# Altium schematic sheet H723ZG_Interface.SchDoc(H723ZG_Interface)
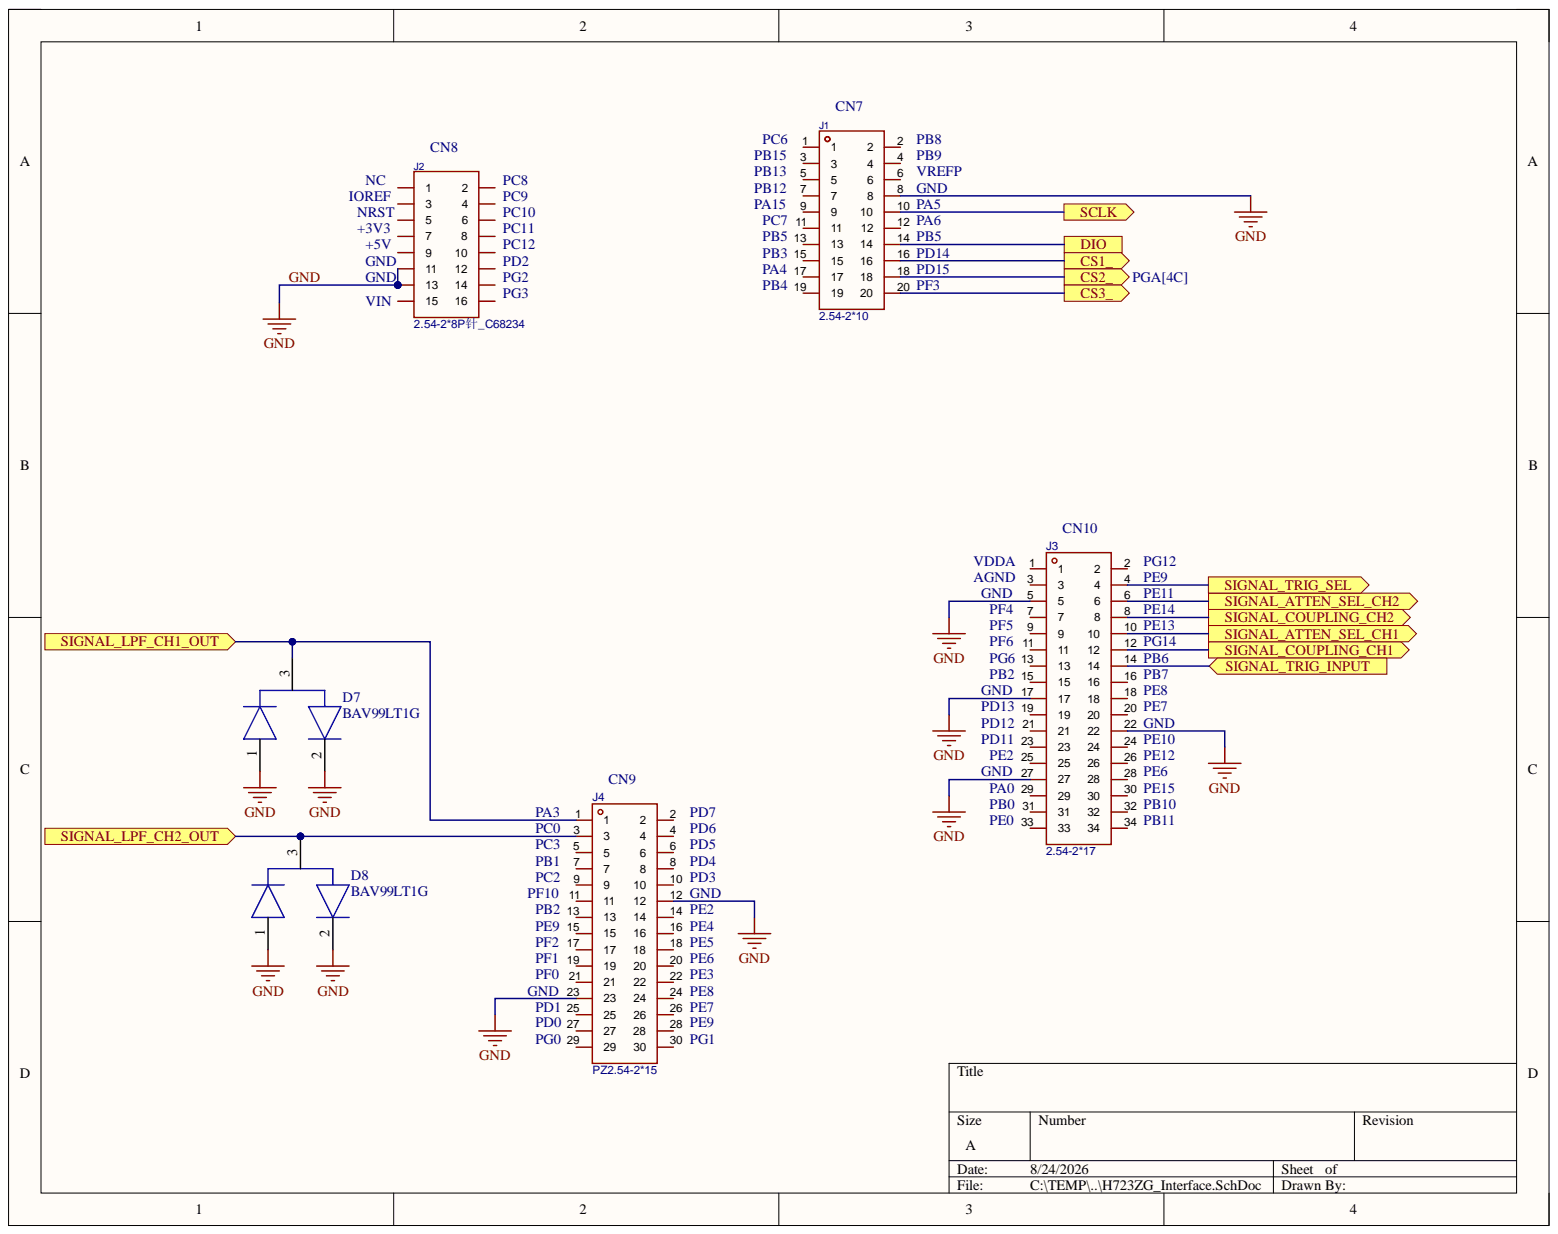
[diagram p0: H723ZG_Interface.SchDoc]

## Parts
D7  BAV99LT1G  [FP-318-08-IPC_B]
D8  BAV99LT1G  [FP-318-08-IPC_B]
J1  2.54-2*10  [HDR-TH_20P-P2.54-V-M-R2-C10-S2.54]
J2  2.54-2*8P?_C68234  [HDR-TH_16P-P2.54-V-M-R2-C8-S2.54]  (value not drawn)
J3  2.54-2*17  [HDR-TH_34P-P2.54-V-M-R2-C17-S2.54-2]
J4  PZ2.54-2*15  [HDR-TH_30P-P2.54-V-M-R2-C15-S2.54-2]

## Ports
CS1_, CS2_, CS3_, DIO, SCLK, SIGNAL_ATTEN_SEL_CH1, SIGNAL_ATTEN_SEL_CH2, SIGNAL_COUPLING_CH1, SIGNAL_COUPLING_CH2, SIGNAL_LPF_CH1_OUT, SIGNAL_LPF_CH2_OUT, SIGNAL_TRIG_INPUT, SIGNAL_TRIG_SEL

## Nets
CS1_: J1.16 ; port CS1_
CS2_: J1.18 ; port CS2_
CS3_: J1.20 ; port CS3_
DIO: J1.14 ; port DIO
GND: D7.1 D7.2 D8.1 D8.2 J1.8 J2.11 J2.13 J3.5 J3.17 J3.22 J3.27 J4.12 J4.23
SCLK: J1.10 ; port SCLK
SIGNAL_ATTEN_SEL_CH1: J3.10 ; port SIGNAL_ATTEN_SEL_CH1
SIGNAL_ATTEN_SEL_CH2: J3.6 ; port SIGNAL_ATTEN_SEL_CH2
SIGNAL_COUPLING_CH1: J3.12 ; port SIGNAL_COUPLING_CH1
SIGNAL_COUPLING_CH2: J3.8 ; port SIGNAL_COUPLING_CH2
SIGNAL_LPF_CH1_OUT: D7.3 J4.1 ; port SIGNAL_LPF_CH1_OUT
SIGNAL_LPF_CH2_OUT: D8.3 J4.3 ; port SIGNAL_LPF_CH2_OUT
SIGNAL_TRIG_INPUT: J3.14 ; port SIGNAL_TRIG_INPUT
SIGNAL_TRIG_SEL: J3.4 ; port SIGNAL_TRIG_SEL

Not connected: J1 1 2 3 4 5 6 7 9 11 12 13 15 17 19; J2 1 2 3 4 5 6 7 8 9 10 12 14 15 16; J3 1 2 3 7 9 11 13 15 16 18 19 20 21 23 24 25 26 28 29 30 31 32 33 34; J4 2 4 5 6 7 8 9 10 11 13 14 15 16 17 18 19 20 21 22 24 25 26 27 28 29 30

## Library data of the parts
D7 D8 = CMP-07163-000036-1: DIODE ARRAY 100V 215MA SOT23-3; Capacitance: 1.5pF; Current Rating: 200mA; Forward Current: 150mA; Forward Voltage: 1.25V; Max Forward Surge Current (Ifsm): 500mA; Max Repetitive Reverse Voltage (Vrrm): 70V; Peak Non-Repetitive Surge Current: 2A; Peak Reverse Current: 2.5uA; Reverse Recovery Time: 6ns; Case/Package: SOT-23; Component Kind: Standard; Height: 0.94mm; Lead Free: Lead Free; Length: 2.9mm; Library Name: Altium Content Vault; Max Operating Temperature: 150°C; Min Operating Temperature: -65°C; Mount: Surface Mount; Number of Pins: 3; Packaging: Tape and Reel; REACH SVHC: No SVHC; Voltage Rating (DC): 70V; Width: 1.3mm
J1 = CMP-012-000002-1: Pin Structure:2x10P Pitch:2.54mm Row Spacing:2.54mm Circluar Pins/Square Pins:Pin Header Mounting Type:Through Hole Number of Rows:2 Number of Pins:20P Current Rating:2.5A Length of Mating Pin:6mm Insulation Height:2.54mm Length of End Connection Pin:3mm; Manufacturer: ZHOURI(洲日); AddInBom: yes; Current Rating: 2.5A; ModelId: 7b46918fe38e4962af531f5fab9490b0?0819f05c4eef4c71ace90d822a990e87; ModelName: HDR-TH_20P-P2.54-V-M-R2-C10-S2.54-1; ModelTransform: 1000.3917,199.9996,0,0,0,0,0,0,-118.11; Pin Structure: 2x10P; Pitch: 2.54mm; Circluar Pins/Square Pins: Pin Header; Component Kind: Standard; Contact Material: Brass; Contact Plating: Gold; ConvertToPcb: yes; Datasheet: https://item.szlcsc.com/datasheet/2.54-2*10/5692723.html; Design Item ID: 2.54-2*10; Device: 2.54-2*10; FootprintName: HDR-TH_20P-P2.54-V-M-R2-C10-S2.54; Insulation Height: 2.54mm; JLCPCB Part Class: Extended Part; LCSC Part Name: 2x10P ??:2.54mm 方? 直插; Length of End Connection Pin: 3mm; Length of Mating Pin: 6mm; Manufacturer Part Number: 2.54-2*10; Mounting Type: Through Hole; Number of Pins: 20P; Number of Rows: 2; Operating Temperature: -40℃~+105℃; Row Spacing: 2.54mm; Source: P1.SCHLIB; Supplier Footprint: 插件,P=2.54mm; Supplier Part Number: C5116480; Symbol: 2.54-2*10; Library Name: zhiming xue
J2 = CMP-012-000001-1: Pin Structure:2x8P Pitch:2.54mm Row Spacing:2.54mm Circluar Pins/Square Pins:Pin Header Mounting Type:Through Hole Number of Rows:2 Number of Pins:16P Current Rating:3A Voltage Rating (Max):- Length of Mating Pin:5.9mm Insulation Height:2.54mm Length of E; Manufacturer: BOOMELE(博穆精密); AddInBom: yes; Current Rating: 3A; ModelId: e9bbe0154d6648aeb599214ae56f6999?0819f05c4eef4c71ace90d822a990e87; ModelName: HDR2.54-M-LI-2X8P; ModelTransform: 799.99844,200,0,0,0,0,0,0,-118.11; Pin Structure: 2x8P; Pitch: 2.54mm; Symbol: Header-Male-2.54_2x8; Circluar Pins/Square Pins: Pin Header; Color: Black; Component Kind: Standard; Contact Material: Brass; Contact Plating: Gold; ConvertToPcb: yes; Datasheet: https://item.szlcsc.com/datasheet/2.54-2*8P%25E9%2592%2588/69336.html; Design Item ID: 2.54-2*8P?_C68234; Device: 2.54-2*8P?_C68234; FootprintName: HDR-TH_16P-P2.54-V-M-R2-C8-S2.54; Insulation Height: 2.54mm; JLCPCB Part Class: Extended Part; LCSC Part Name: 2x8P ??:2.54mm 方? 直插; Length of End Connection Pin: 3mm; Length of Mating Pin: 5.9mm; Manufacturer Part Number: 2.54-2*8P?; Mounting Type: Through Hole; Number of Pins: 16P; Number of Rows: 2; Operating Temperature: -55℃~+105℃; Row Spacing: 2.54mm; Source: P1.SCHLIB; Supplier Footprint: 插件,P=2.54mm; Supplier Part Number: C68234; Voltage Rating (Max): -; Library Name: zhiming xue
J3 = CMP-012-000006-1: 2.54mm pitch, 2 rows, 2*17, 34 pins total; Component Kind: Standard; Library Name: zhiming xue
J4 = CMP-012-000005-1: Pin Structure:2x15P Pitch:2.54mm Row Spacing:2.54mm Circluar Pins/Square Pins:Pin Header Mounting Type:Through Hole Number of Rows:2 Number of Pins:30P Current Rating:2.5A Length of Mating Pin:6mm Insulation Height:2.54mm Length of End Connection Pin:3mm; Manufacturer: ZHOURI(洲日); AddInBom: yes; Current Rating: 2.5A; ModelId: 20e3c55689c04fa0b6843741cd965711?0819f05c4eef4c71ace90d822a990e87; ModelTransform: 1500.036,196.85,0,0,0,0,0,0,-118.11; Pin Structure: 2x15P; Pitch: 2.54mm; Circluar Pins/Square Pins: Pin Header; Component Kind: Standard; Contact Material: Brass; Contact Plating: Gold; ConvertToPcb: yes; Datasheet: https://item.szlcsc.com/datasheet/PZ2.54-2*15/5925192.html; Design Item ID: PZ2.54-2*15; Device: PZ2.54-2*15; FootprintName: HDR-TH_30P-P2.54-V-M-R2-C15-S2.54-2; Insulation Height: 2.54mm; JLCPCB Part Class: Extended Part; LCSC Part Name: 2x15P ??:2.54mm 方? 直插; Length of End Connection Pin: 3mm; Length of Mating Pin: 6mm; Manufacturer Part Number: PZ2.54-2*15; ModelName: HDR-TH_30P-P2.54-V-M-R2-C15-S2.54-2; Mounting Type: Through Hole; Number of Pins: 30P; Number of Rows: 2; Operating Temperature: -40℃~+105℃; Row Spacing: 2.54mm; Source: P1.SCHLIB; Supplier Footprint: 插件,P=2.54mm; Supplier Part Number: C5224013; Symbol: PZ2.54-2*15; Library Name: zhiming xue
